# Altium schematic sheet MSXIV_BatteryModuleConnectorBoard.SchDoc
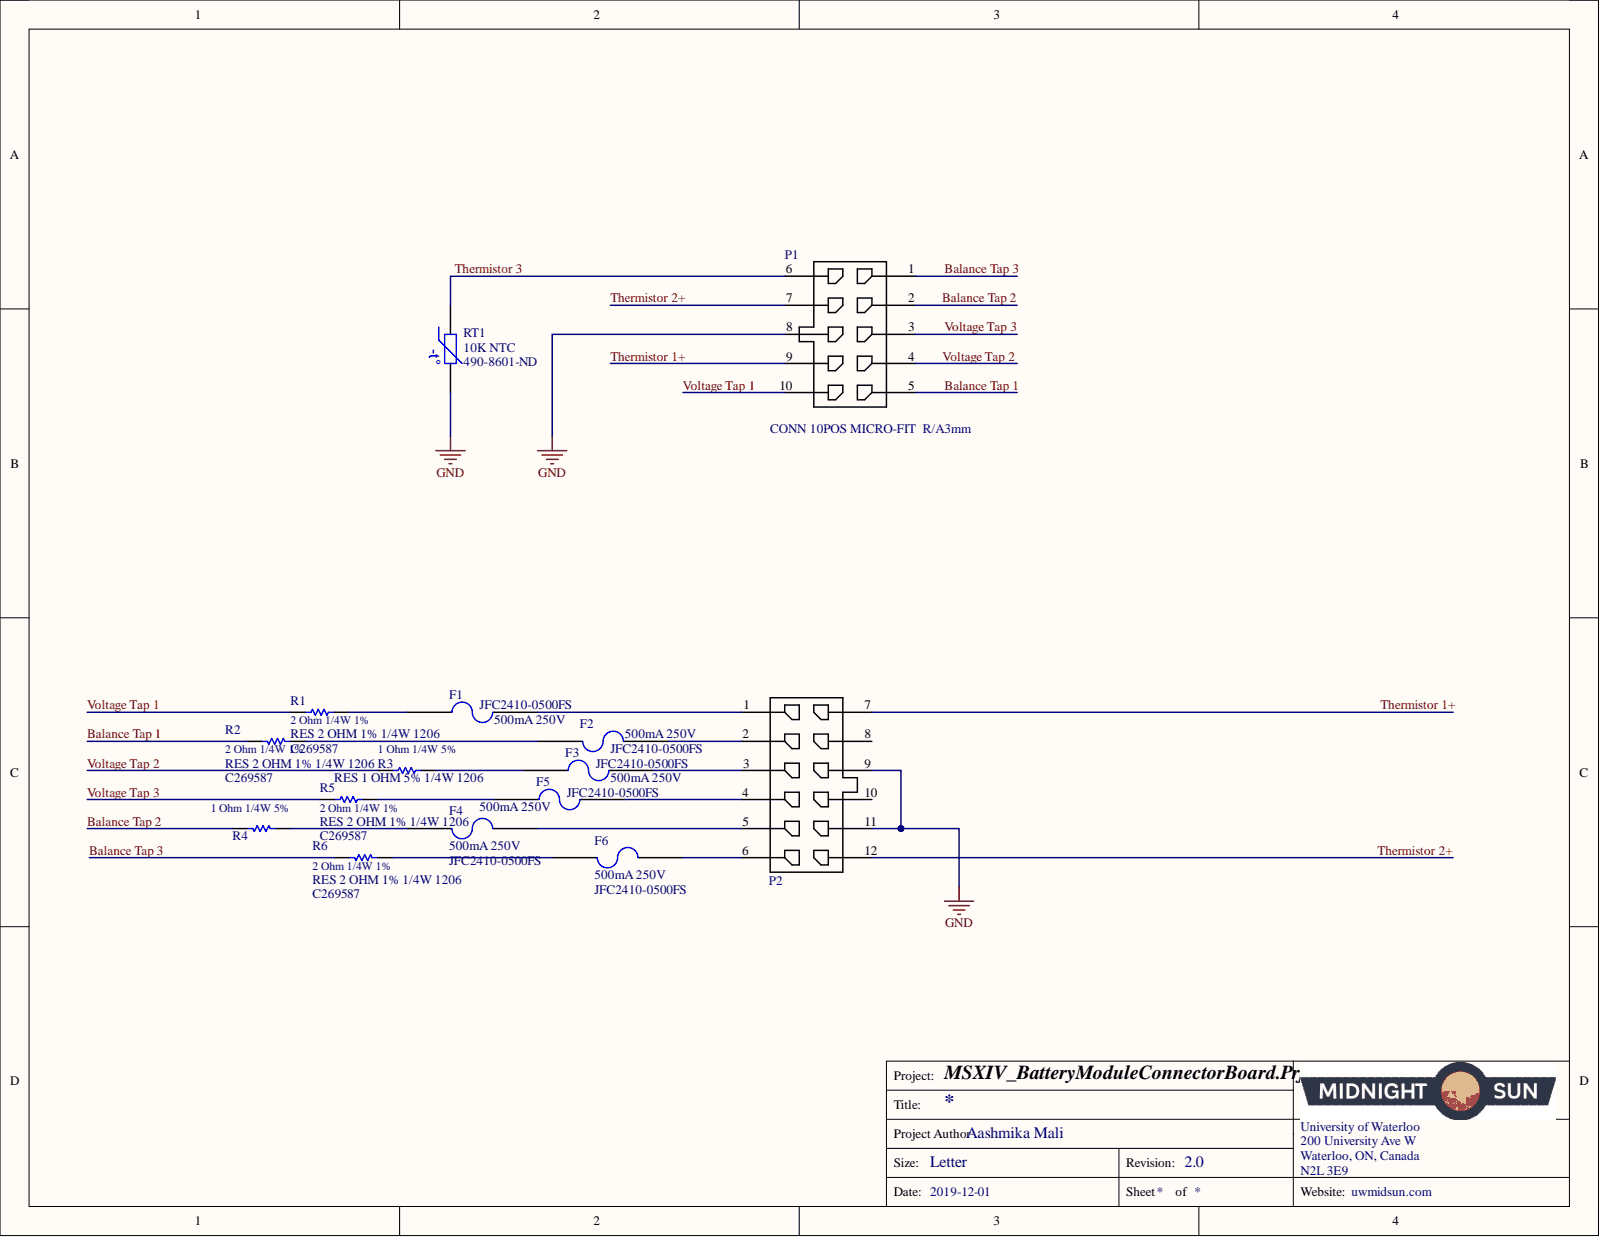
[diagram p0: MSXIV_BatteryModuleConnectorBoard.SchDoc]

## Parts
F1  500mA 250V  Manufacturer Part Number 1: JFC2410-0500FS  [FUSE JFC2410-0500FS]
F2  500mA 250V  Manufacturer Part Number 1: JFC2410-0500FS  [FUSE JFC2410-0500FS]
F3  500mA 250V  Manufacturer Part Number 1: JFC2410-0500FS  [FUSE JFC2410-0500FS]
F4  500mA 250V  [FUSE JFC2410-0500FS]
F5  500mA 250V  Manufacturer Part Number 1: JFC2410-0500FS  [FUSE JFC2410-0500FS]
F6  500mA 250V  Manufacturer Part Number 1: JFC2410-0500FS  [FUSE JFC2410-0500FS]
P1  CONN 10POS MICRO-FIT  R/A3mm  [CONN, 10POS R/A Microfit]
P2  CONN 12POS HEADER R/A MICROFIT 3MM  [CONN, 12POS R/A Microfit]  (value not drawn)
R1  RES 2 OHM 1% 1/4W 1206  [RES 1206]
R2  RES 2 OHM 1% 1/4W 1206  Supplier Part Number 1: C269587  [RES 1206]
R3  RES 1 OHM 5% 1/4W 1206  [RES 1206]
R4  RES 1 OHM 5% 1/4W 1206  [RES 1206]
R5  RES 2 OHM 1% 1/4W 1206  [RES 1206]
R6  RES 2 OHM 1% 1/4W 1206  Supplier Part Number 1: C269587  [RES 1206]
RT1  10K NTC  Supplier Part Number 1: 490-8601-ND  [NTC THERMISTOR BEAD]

## Nets
GND: P1.8 P2.9 P2.11 RT1.2
Balance Tap 1: P1.5 R2.2
Balance Tap 2: P1.2 R4.2
Balance Tap 3: P1.1 R6.2
Thermistor 1+: P1.9 P2.7
Thermistor 2+: P1.7 P2.12
Thermistor 3: P1.6 RT1.1
Voltage Tap 1: P1.10 R1.1
Voltage Tap 2: P1.4 R3.1
Voltage Tap 3: P1.3 R5.1
NetF1_1: F1.1 R1.2
NetF1_2: F1.2 P2.1
NetF2_1: F2.1 P2.2
NetF2_2: F2.2 R2.1
NetF3_1: F3.1 R3.2
NetF3_2: F3.2 P2.3
NetF4_1: F4.1 P2.5
NetF4_2: F4.2 R4.1
NetF5_1: F5.1 R5.2
NetF5_2: F5.2 P2.4
NetF6_1: F6.1 P2.6
NetF6_2: F6.2 R6.1

Not connected: P2 8 10

## Library data of the parts
F1-F3 F5 F6 = FUSE 500MA LCSC: 2410 Surface Mount Fuses RoHS; Manufacturer 1: Shenzen JDT Fuse; Component Kind: Standard; ComponentLink1Description: Shenzhen JDT Fuse; ComponentLink1URL: https://datasheet.lcsc.com/szlcsc/1809211424_Shenzhen-JDT-Fuse-JFC2410-0500FS_C136360.pdf; Pricing 1: 1=0.0591USD; Supplier 1: LCSC; Supplier Part Number 1: C136360; Library Name: Schematic Diagrams.SchLib
F4 = FUSE 500MA LCSC: 2410 Surface Mount Fuses RoHS; Manufacturer 1: Shenzen JDT Fuse; Manufacturer Part Number 1: JFC2410-0500FS; Component Kind: Standard; ComponentLink1Description: Shenzhen JDT Fuse; ComponentLink1URL: https://datasheet.lcsc.com/szlcsc/1809211424_Shenzhen-JDT-Fuse-JFC2410-0500FS_C136360.pdf; Pricing 1: 1=0.0591USD; Supplier 1: LCSC; Supplier Part Number 1: C136360; Library Name: Schematic Diagrams.SchLib
P1 = CONN 10POS MICRO-FIT  R/A3mm: CONN HEADER R/A 10POS 3MM; Manufacturer 1: Molex; Manufacturer Part Number 1: 0430451000; Connector Type: Male Pin; Contact Resistance: 10mR; Max Voltage Rating (AC): 250V; Number of Contacts: 10; Row Spacing: 0.118inch; Category: Headers and Wire Housings; Color: Black; Component Kind: Standard; ComponentLink1Description: https://datasheet.ciiva.com/6814/430450202-sd-6814012.pdf?src-supplier=Digi-Key; ComponentLink1URL: https://datasheet.ciiva.com/6814/430450202-sd-6814012.pdf?src-supplier=Digi-Key; Contact Material: Brass; Contact Plating: Tin; Depth: 12.2mm; Fastening Type: Ramp; Flammability Rating: UL94 V-0; Housing Color: Black; Housing Material: Polymer; Length: 19.15mm; Max Operating Temperature: 105°C; Min Operating Temperature: -40°C; Mount: Through Hole; Number of Positions: 10; Number of Rows: 2; Orientation: Right Angle; Packaging: Tray; Pitch: 3mm; Pricing 1: 1=2.57000, 10=2.33100, 100=1.99790, 500=1.66496, 1000=1.42710, 5000=1.26536 (USD); RoHS: Yes; Stock 1: 2003; Supplier 1: Digi-Key; Supplier Part Number 1: WM1817-ND; Termination: Solder; Library Name: Schematic Diagrams.SchLib
P2 = CONN 12POS HEADER R/A 3MM: Conn Power HDR 12 POS 3mm Solder RA Thru-Hole 12 Terminal 1 Port Micro-Fit 3.0™ Tray; Manufacturer 1: Molex; Manufacturer Part Number 1: 0430451200; Connector Type: Male Pin; Contact Resistance: 10mR; Max Voltage Rating (AC): 250V; Number of Contacts: 12; Row Spacing: 0.118inch; Category: Headers and Wire Housings; Color: Black; Component Kind: Standard; ComponentLink1Description: https://datasheet.ciiva.com/6814/430450202-sd-6814012.pdf?src-supplier=Digi-Key; ComponentLink1URL: https://datasheet.ciiva.com/6814/430450202-sd-6814012.pdf?src-supplier=Digi-Key; Contact Material: Brass; Contact Plating: Tin; Depth: 12.2mm; Fastening Type: Ramp; Flammability Rating: UL94 V-0; Housing Color: Black; Housing Material: Polymer; Length: 22.15mm; Max Operating Temperature: 105°C; Min Operating Temperature: -40°C; Mount: Through Hole; Number of Positions: 12; Number of Rows: 2; Orientation: Right Angle; Packaging: Tray; Pitch: 3mm; Pricing 1: 1=2.73000, 10=2.62200, 100=2.18500, 500=1.85726, 1000=1.58413, 5000=1.42025 (USD); RoHS: Yes; Stock 1: 5742; Supplier 1: Digi-Key; Supplier Part Number 1: WM1818-ND; Termination: Solder; Library Name: Schematic Diagrams.SchLib
R1 R2 R5 R6 = RES 2 OHM 1% 1/4W 1206: 2 ±1% 1/4W 1206 Chip Resistor - Surface Mount RoHS; Manufacturer 1: TyoHM; Manufacturer Part Number 1: RMC120621%N; Power Rating: 250mW; Resistance: 2R; Voltage Rating (DC): 200V; Case/Package: 1206; Category: Chip SMD Resistors; Component Kind: Standard; Lead Free: Lead Free; RoHS: No; Supplier 1: LCSC; Library Name: Schematic Diagrams.SchLib
  R1 R5: Supplier Part Number 1: C269587
R3 R4 = RES 1 OHM 5% 1/4W 1206: 1 ±5% 1/4W 1206 Chip Resistor - Surface Mount RoHS; Manufacturer 1: TyoHM; Manufacturer Part Number 1: RMC120615%N; Component Kind: Standard; Supplier 1: LCSC; Supplier Part Number 1: C325901; Library Name: Schematic Diagrams.SchLib
RT1 = NTC THERMISTOR 10K 1% BEAD: NTC Thermistor 10k Bead; Manufacturer: Murata Electronics North America; Manufacturer Part Number: NXRT15XH103FA1B030; B Value Tolerance: ±1%; B25/100: 3455K; B25/50: 3380K; B25/85: 3434K; B0/50: -; B25/75: -; Category: Sensors, Transducers; Component Kind: Standard; ComponentLink1Description: https://www.murata.com/~/media/webrenewal/support/library/catalog/products/thermistor/ntc/r44e.ashx; ComponentLink1URL: https://www.murata.com/~/media/webrenewal/support/library/catalog/products/thermistor/ntc/r44e.ashx; Length - Lead Wire: -; Mounting Type: Through Hole; Operating Temperature: -40°C ~ 125°C; Package / Case: Bead; Packaging: Bulk; Part Status: Active; Pricing 1: 1=0.96, 5=0.91, 10=0.792, 25=0.696, 50=0.5784, 100=0.4925, 500=0.42834, 1000=0.3748, 5000=0.33197 (CAD); Resistance in Ohms @ 25°C: 10k; Resistance Tolerance: ±1%; RoHS: RoHS Compliant; Stock 1: 25017; Supplier 1: Digi-Key; Library Name: Schematic Diagrams.SchLib
